# Altium schematic sheet ADCS C2Sv0.1 - Shield_TopLevel.SchDoc("ADCS C2Sv0.1 - Shield_TopLevel")
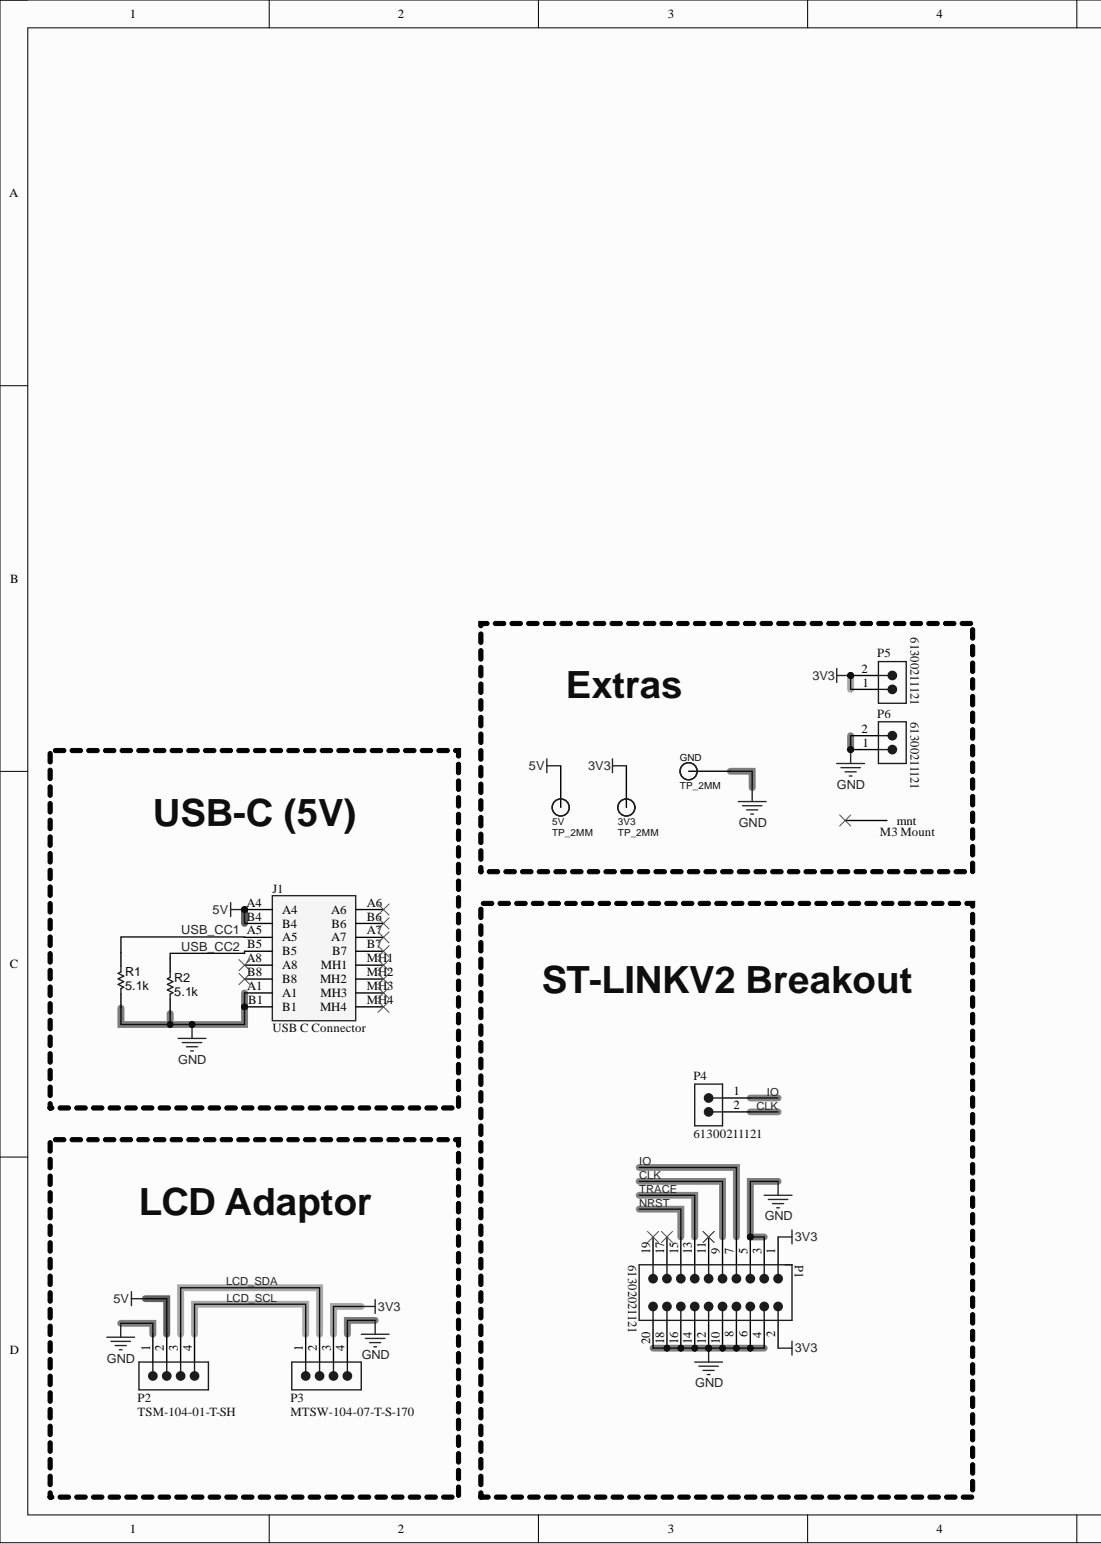
[diagram p0: ADCS C2Sv0.1 - Shield_TopLevel.SchDoc, left]
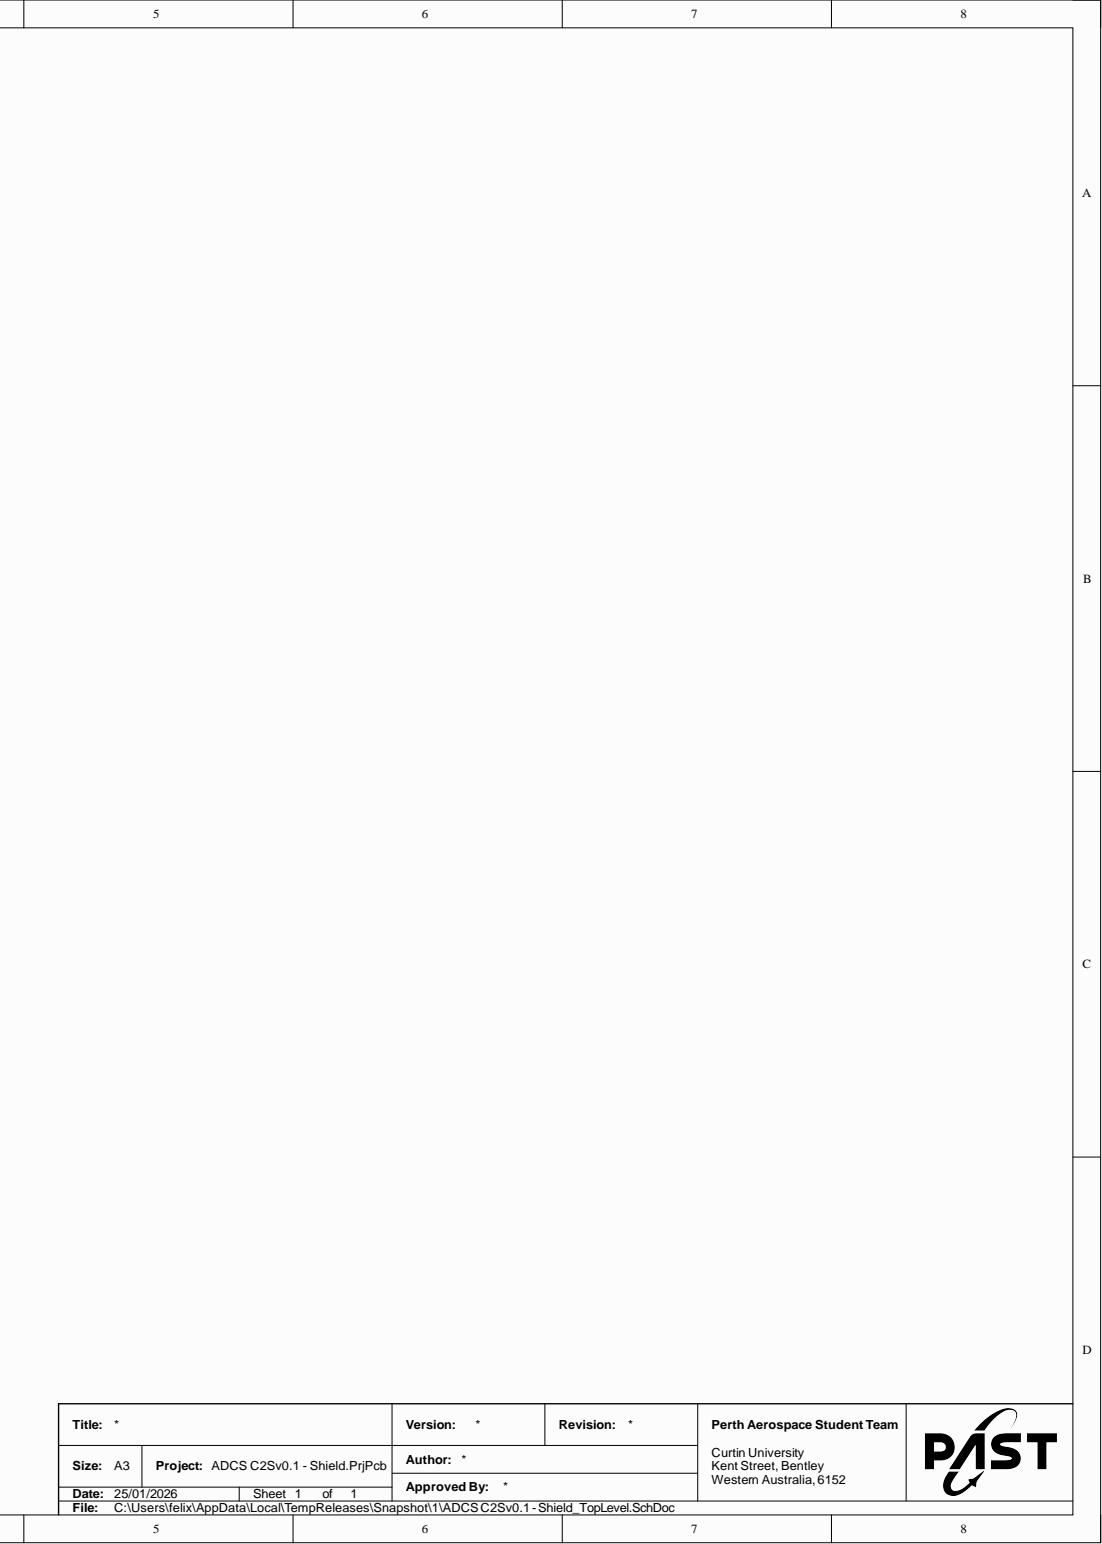
[diagram p1: ADCS C2Sv0.1 - Shield_TopLevel.SchDoc, right]

## Parts
3V3  TP_2MM  [TESTPOINT 2MM]
5V  TP_2MM  [TESTPOINT 2MM]
GND  TP_2MM  [TESTPOINT 2MM]
J1  USB C Connector  [UJ20CHGMSMT2P16TR]
MNT1  M3 Mount  [M3_Mount-Footprint-1]
P1  61302021121  [61302021121]
P2  TSM-104-01-T-SH  [SMTC-TSM-104-01-X-SH]
P3  MTSW-104-07-T-S-170  [SMTC-MTSW-104-07-X-S-170]
P4  61300211121  [61300211121]
P5  61300211121  [61300211121]
P6  61300211121  [61300211121]
R1  value 5.1k  [RESC1608X55X25ML10T15-1]  (hidden comment: Resistor 10k +/-1% 0603 100mW)
R2  value 5.1k  [RESC1608X55X25ML10T15-1]  (hidden comment: Resistor 10k +/-1% 0603 100mW)

## Nets
3V3: 3V3.TP P1.1 P1.2 P3.3 P5.1 P5.2
5V: 5V.TP J1.A4 J1.B4 P2.2
GND: GND.TP J1.A1 J1.B1 P1.3 P1.4 P1.5 P1.6 P1.8 P1.10 P1.12 P1.14 P1.16 P1.18 P1.20 P2.1 P3.4 P6.1 P6.2 R1.2 R2.2
CLK: P1.9 P4.2
IO: P1.7 P4.1
LCD_SCL: P2.4 P3.1
LCD_SDA: P2.3 P3.2
NRST: P1.15
TRACE: P1.13
USB_CC1: J1.A5 R1.1
USB_CC2: J1.B5 R2.1

Not connected: J1 A6 A7 A8 B6 B7 B8 MH1 MH2 MH3 MH4; MNT1 1; P1 11 17 19

## Library data of the parts
J1 = CMP-026-000123-2: UJ20-C-H-G-MSMT-2-P16-TR Connector; Manufacturer_Name: Same Sky; Component Kind: Standard; Datasheet Link: https://cz.mouser.com/ProductDetail/Same-Sky/UJ20-C-H-G-MSMT-2-P16-TR?qs=IKkN%2F947nfBldcIwp9d6BA%3D%3D; Design Item ID: UJ20-C-H-G-MSMT-2-P16-TR; Height: 3.36mm; Manufacturer_Part_Number: UJ20-C-H-G-MSMT-2-P16-TR; Mouser Part Number: 179-UJ20CHGMSMT2P16T; Mouser Price/Stock: https://www.mouser.co.uk/ProductDetail/Same-Sky/UJ20-C-H-G-MSMT-2-P16-TR?qs=IKkN%2F947nfBldcIwp9d6BA%3D%3D; Source: SamacSys.SchLib; Author: Raphael Ho; Library Name: Perth Aerospace Student Team
MNT1 = CMP-002-00124-2: M3 mounting hole; Component Kind: Standard; Author: Raphael Ho; Library Name: Perth Aerospace Student Team
P1 = CMP-1502-01104-4: THT Vertical Pin Header WR-PHD, Pitch 2.54 mm, Dual Row, 20 pins; Connector Type: Male Pin; Contact Resistance: 20mΩ; Current Rating: 3A; Gender: Male; Number of Contacts: 20; Operating Supply Voltage: 250V; Pitch: 2.54mm; Color: Black; Component Kind: Standard; Contact Material: Gold; Contact Mating Length: 5.9944mm; Contact Plating: Gold; Height: 8.89mm; Lead Pitch: 2.54mm; Library Name: Altium Content Vault; Max Operating Temperature: 105°C; Min Operating Temperature: -40°C; Mount: Through Hole; Number of Pins: 20; Number of Positions: 20; Number of Rows: 2; Orientation: Vertical; Packaging: Bulk; RoHS: Compliant; Row Spacing: 2.54mm; Termination: Solder; Voltage Rating: 250V
P2 = CMP-1024-00223-1: 0.025" SQ Post Header, Surface Mount, Horizontal, -55 to 105 degC, 2.54 mm Pitch, 4-Pin, Male, RoHS; Manufacturer: Samtec; Pitch: 2.54 mm; Component Kind: Standard; ComponentLink1Description: Manufacturer URL; ComponentLink1URL: http://www.samtec.com; ComponentLink2Description: Datasheet; ComponentLink2URL: http://www.samtec.com/documents/webfiles/pdf/TSM.PDF; ComponentLink3Description: Package Specification; ComponentLink3URL: http://www.samtec.com/documents/webfiles/cpdf/TSM-1XX-XX-XXX-SH-XX-XXX-XX-MKT1.pdf; DatasheetVersion: F-214; Library Name: Altium Content Vault; Mounting Technology: Surface Mount; Orientation: Horizontal; PackageDescription: Terminal Strip Single Surface Mount; PackageReference: TSM-104-01-X-SH; PackageVersion: CD, 7/1991; PartNumber: TSM-104-01-T-SH; RoHS: True; Temperature Range: -55 to 105 degC
P3 = CMP-1024-00105-1: Modified .025" SQ Post Header, Through-hole, Vertical, -55 to 105 degC, 2.54 mm Pitch, 4-Pin, Male, RoHS; Manufacturer: Samtec; Pitch: 2.54 mm; Component Kind: Standard; ComponentLink1Description: Manufacturer URL; ComponentLink1URL: http://www.samtec.com; ComponentLink2Description: Datasheet; ComponentLink2URL: http://www.samtec.com/documents/webfiles/pdf/MTSW.PDF; ComponentLink3Description: Package Specification; ComponentLink3URL: http://www.samtec.com/documents/webfiles/cpdf/MTSW-XXX-XX-X-X-XXXX-XX-XXX-MKT1.pdf; DatasheetVersion: F-214; Library Name: Altium Content Vault; Mounting Technology: Through-hole; Orientation: Vertical; PackageDescription: Assembly .025" [.64] SQ Post Terminal Strip; PackageReference: MTSW-104-07-X-S-170; PackageVersion: BI, 2/1987; PartNumber: MTSW-104-07-T-S-170; RoHS: True; Temperature Range: -55 to 105 degC
P4-P6 = CMP-096-000133-1: WR-PHD Pin Header, THT, Vertical, pitch 2.54mm, 1 Row, 2P; Manufacturer: Wurth Elektronik; Contact Resistance: 20mOhm; Rated Current: 3A; Withstanding Voltage: 500V; Working Voltage: 250V; Category: Board-to-Board Connectors; Component Kind: Standard; Component Type: Standard; ComponentLink1Description: Website Link; ComponentLink1URL: https://www.we-online.com/redexpert/article/61300211121?ad; ComponentLink2Description: Datasheet Link; ComponentLink2URL: https://www.we-online.com/redexpert/spec/61300211121?ad; Design Item ID: 61300211121; Gender: Pin Header; Manufacturer Part Number: 61300211121; Match Code: WR-PHD; Mount: Through Hole; Number of Pins: 2; Number of Rows: 1; Operating Temperature Max: 105°C; Operating Temperature Min: -40°C; Orientation: Vertical; Packaging: Bag; Pin Count: 2; Pitch: 2.54mm; Source: WR-PHD.SchLib; Library Name: Perth Aerospace Student Team
R1 R2 = CMP-009-00181-1: Chip Resistor, 10k Ohm, 0.1 W, -55 to 155 degC, 0603 (1608 Metric); Tolerance: 1%; Voltage Rating: 50V; Case/Package: 0603; Component Kind: Standard; Design Item ID: CMP-009-00006-5; Max Operating Temperature: 155°C; Min Operating Temperature: -55°C; Mounting Technology: SM; Pins: 2; Power: 100mW; RoHS Compliant: Yes; Source: Components - 14.11.22 - 11.28.SchLib; Created by: Micah Randall; Library Name: Perth Aerospace Student Team
3V3 5V GND = TP_2MM: Component Kind: Standard; Library Name: C2S.SchLib
